# Altium schematic sheet Sheet 4 - Capteurs.SchDoc("Sheet 4 - Capteurs")
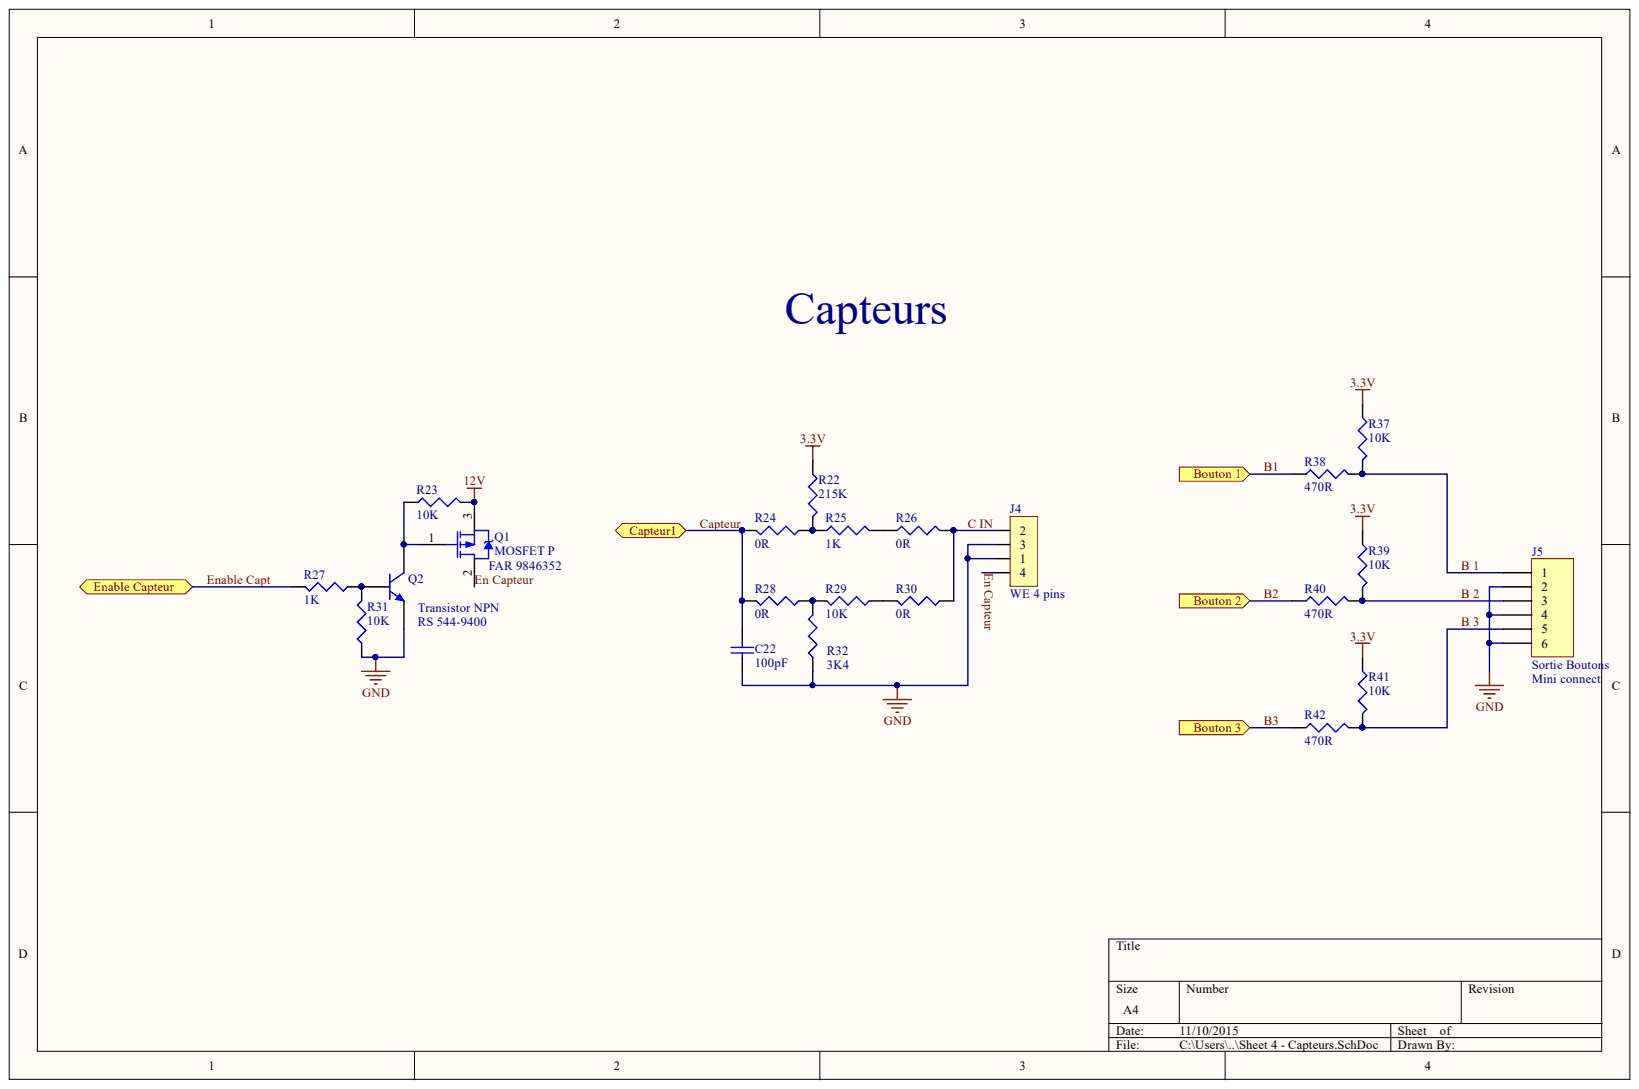
[diagram p0: Sheet 4 - Capteurs.SchDoc]

## Parts
C22  100pF  [C0805]
J4  WE 4 pins  [690367280476]
J5  Mini connect  value Sortie Boutons  [690367280676]
Q1  MOSFET P  référence commande: FAR 9846352  [SOT-23]
Q2  Transistor NPN  [SOT23_L]
R22  215K  [0805]
R23  10K  [0805]
R24  0R  [0805]
R25  1K  [0805]
R26  0R  [0805]
R27  1K  [0805]
R28  0R  [0805]
R29  10K  [0805]
R30  0R  [0805]
R31  10K  [0805]
R32  3K4  [0805]
R37  10K  [0805]
R38  470R  [0805]
R39  10K  [0805]
R40  470R  [0805]
R41  10K  [0805]
R42  470R  [0805]

## Ports
BOUTON 1, BOUTON 2, BOUTON 3, CAPTEUR1, ENABLE CAPTEUR

## Nets
3.3V: R22.1 R37.1 R39.1 R41.1
12V: Q1.3 R23.2
GND: C22.2 J4.1 J4.3 J5.2 J5.4 J5.6 Q2.2 R31.1 R32.1
B1: R38.1 ; port BOUTON 1
B2: R40.1 ; port BOUTON 2
B3: R42.1 ; port BOUTON 3
B 1: J5.1 R37.2 R38.2
B 2: J5.3 R39.2 R40.2
B 3: J5.5 R41.2 R42.2
C IN: J4.2 R26.2 R30.1
CAPTEUR: C22.1 R24.2 R28.2 ; port CAPTEUR1
EN CAPTEUR: J4.4 Q1.2
ENABLE CAPT: R27.2 ; port ENABLE CAPTEUR
NetQ1_1: Q1.1 Q2.3 R23.1
NetQ2_1: Q2.1 R27.1 R31.2
NetR22_2: R22.2 R24.1 R25.1
NetR25_2: R25.2 R26.1
NetR28_1: R28.1 R29.2 R32.2
NetR29_1: R29.1 R30.2

## Library data of the parts
C22 = Cap: Capacitor 0805; Component Kind: Standard; LatestRevisionDate: 17-Jul-2002; LatestRevisionNote: Re-released for DXP Platform.; Library Name: Miscellaneous Devices.IntLib; PackageReference: RAD-0.3; Published: 8-Jun-2000; Signal Integrity: Cap; Simulation: CAP; Value: 100pF; Publisher: Altium Limited
J4 = MICRO-MATCH FOB.04P: connecteur WE; Component Kind: Standard; Library Name: lib_peb_1.SchLib
J5 = MHDR1X6: Header, 6-Pin; Component Kind: Standard; LatestRevisionDate: 17-Jul-2002; LatestRevisionNote: Re-released for DXP Platform.; Library Name: Miscellaneous Connectors.IntLib; Signal Integrity: Connector; Publisher: Altium Limited
Q1 = PMOS-2: P-Channel Power MOSFET; Note: Pin 4 not drawn and electrically connected to Pin 2.; Code_JEDEC: TO-220-AB; Component Kind: Standard; ComponentLink1Description: Manufacturer Link; ComponentLink1URL: http://www.irf.com/; DatasheetVersion: PD-9.390D; LatestRevisionDate: 17-Jul-2002; LatestRevisionNote: Re-released for DXP Platform.; Library Name: Miscellaneous Devices.IntLib; PackageReference: TO-220AB; Published: 8-Jun-2000; Simulation: IRF9510; Publisher: Altium Limited
Q2 = QNPN: NPN Bipolar Transistor; référence commande: RS 544-9400; Component Kind: Standard; LatestRevisionDate: 29-May-2009; LatestRevisionNote: IPC-7351 Footprint Added.; Library Name: Miscellaneous Devices.IntLib; PackageDescription: 3-Pin SOT-23 Package 0.95 mm Pitch, 2.4 mm Lead Span; PackageReference: SOT-23B; PackageVersion: Aug-1999; Published: 8-Jun-2000; Signal Integrity: NPN; Simulation: QNPN; Publisher: Altium Limited
R22-R32 = Res3: Resistor 0805; Component Kind: Standard; LatestRevisionDate: 29-May-2009; LatestRevisionNote: IPC-7351 Footprint Added.; Library Name: Miscellaneous Devices.IntLib; PackageDescription: Chip Resistor; PackageReference: J1-0603; Published: 8-Jun-2000; Signal Integrity: Res; Simulation: RESISTOR; Publisher: Altium Limited
  R22: Value: 215K
  R23 R29 R31: Value: 10K
  R24 R26 R28 R30: Value: 0R
  R25 R27: Value: 1K
  R32: Value: 3k4
R37-R42 = Res3: Resistor 0805; Value: 0R; Component Kind: Standard; LatestRevisionDate: 29-May-2009; LatestRevisionNote: IPC-7351 Footprint Added.; Library Name: Miscellaneous Devices.IntLib; PackageDescription: Chip Resistor; PackageReference: J1-0603; Published: 8-Jun-2000; Signal Integrity: Res; Simulation: RESISTOR; Publisher: Altium Limited
